# Altium schematic sheet ELRS_E19.SchDoc
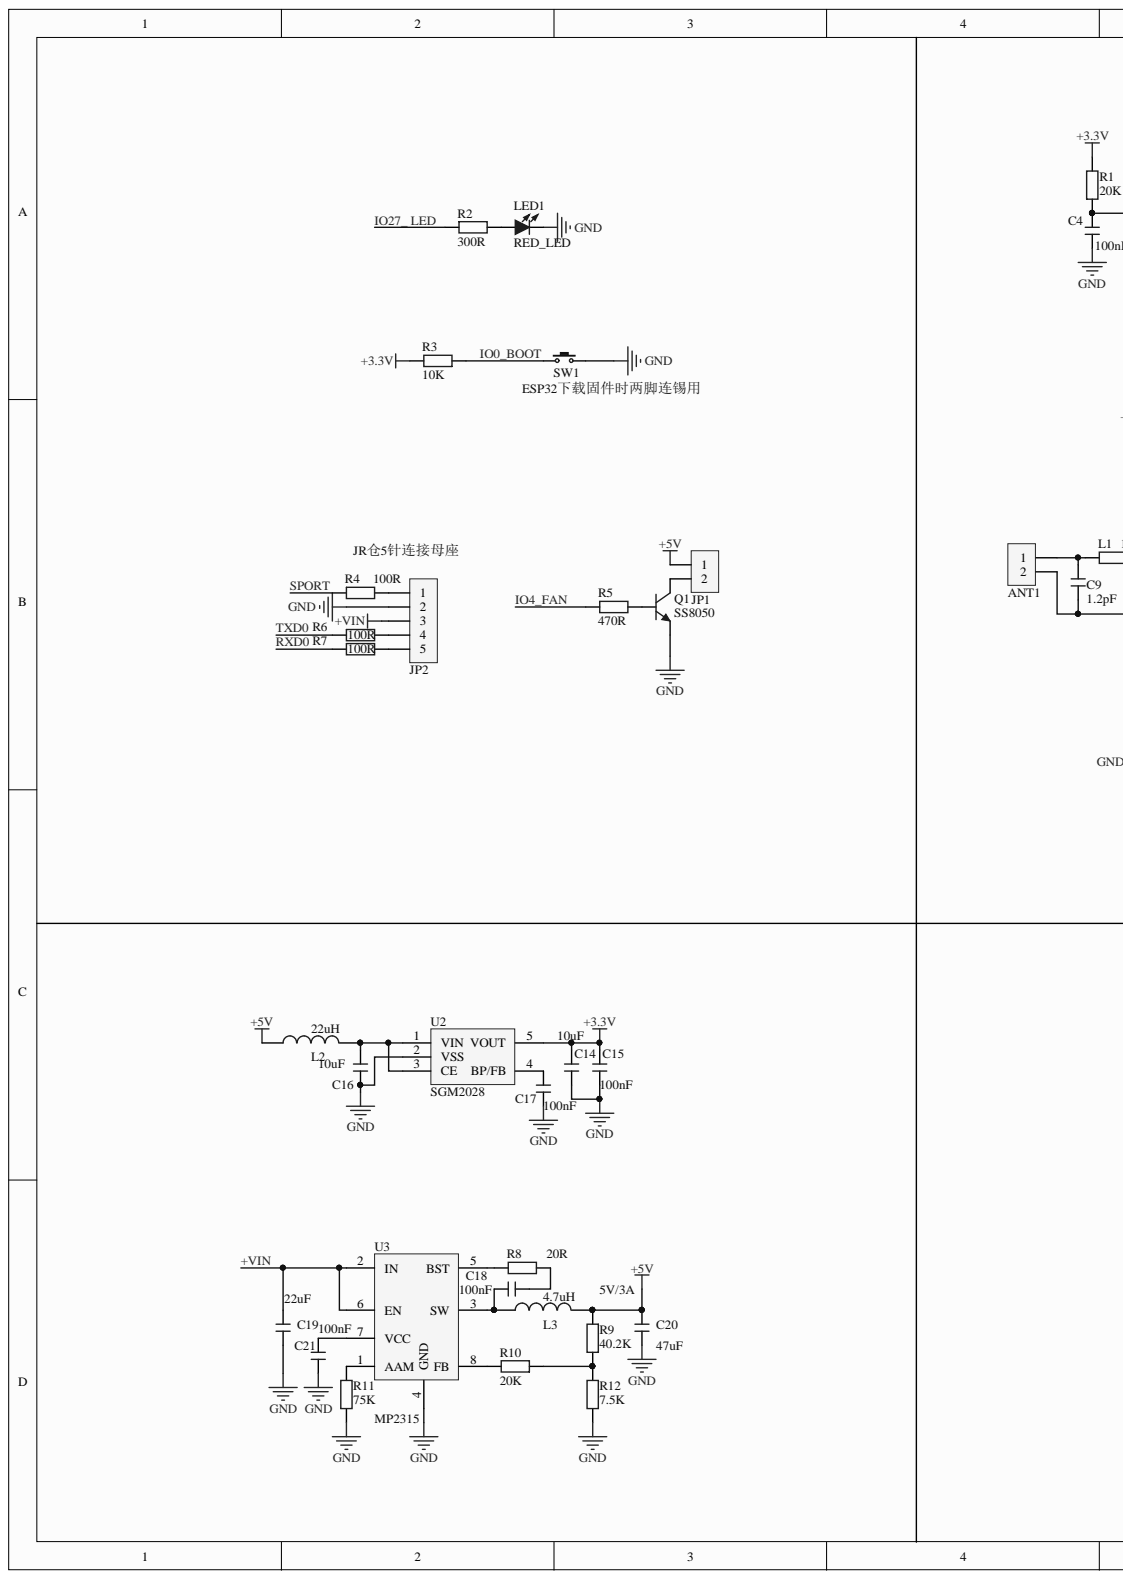
[diagram p0: ELRS_E19.SchDoc, left]
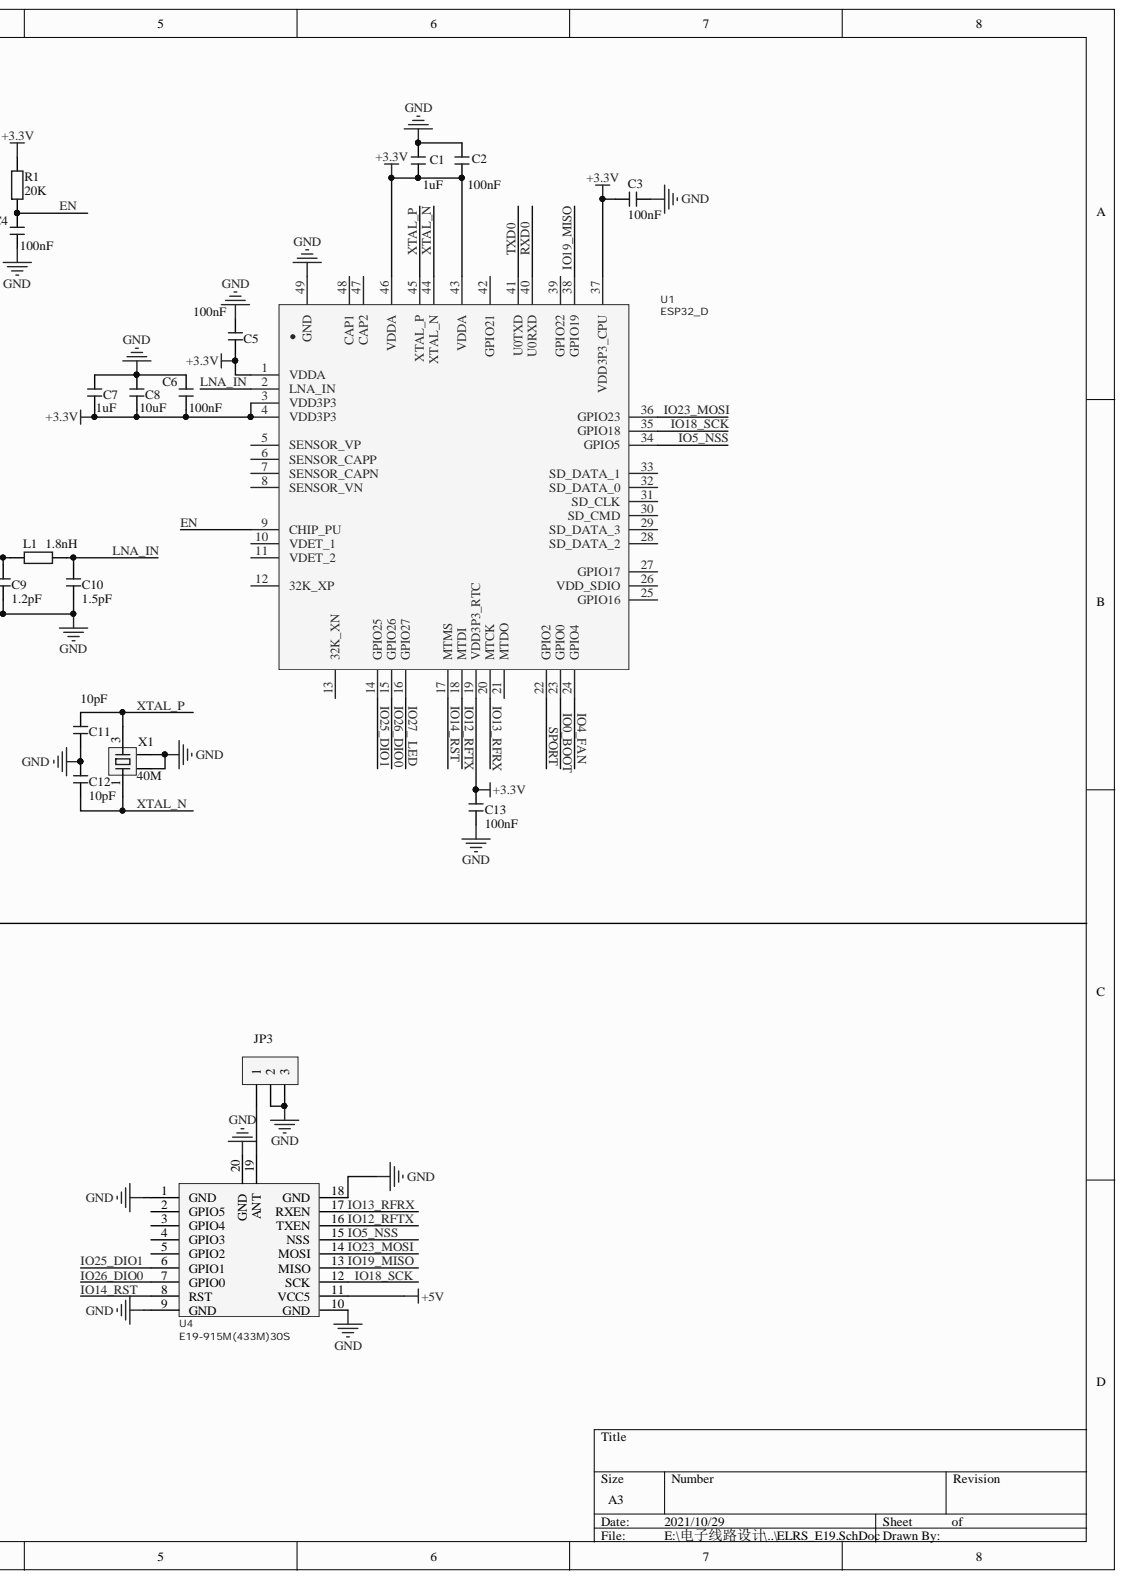
[diagram p1: ELRS_E19.SchDoc, right]

## Parts
ANT1  (no value)  [MINI_ANT]
C1  1uF  [C0402S]
C2  100nF  [C0402S]
C3  100nF  [C0402S]
C4  100nF  [C0402S]
C5  100nF  [C0402S]
C6  100nF  [C0402S]
C7  1uF  [C 0603_L]
C8  10uF  [C 0603_L]
C9  1.2pF  [C0402S]
C10  1.5pF  [C0402S]
C11  10pF  [C0402S]
C12  10pF  [C0402S]
C13  100nF  [C0402S]
C14  10uF  [C 0603_L]
C15  100nF  [C 0603_L]
C16  10uF  [C 0603_L]
C17  100nF  [C 0402_L]
C18  100nF  [C0402S]
C19  22uF  [C 1210_L]
C20  47uF  [C 1210_L]
C21  100nF  [C0402S]
JP1  HDR-1X2  [MX 1.25-LI-2P]  (value not drawn)
JP2  B2541BW-5P  [B2541BW-5P]  (value not drawn)
JP3  IPEX  [IPEX]  (value not drawn)
L1  1.8nH  [L0402S]
L2  22uH  [L 0603]
L3  4.7uH  [CD42]
LED1  RED_LED  [LED0805TB]
Q1  SS8050  [SOT23-3L]
R1  20K  [R0402S]
R2  300R  [R0402S]
R3  10K  [R0402S]
R4  100R  [R0402S]
R5  470R  [R0402S]
R6  100R  [R0402S]
R7  100R  [R0402S]
R8  20R  [R0402S]
R9  40.2K  [R0402S]
R10  20K  [R0402S]
R11  75K  [R0402S]
R12  7.5K  [R0402S]
SW1  FLASH  [jumper-2.0]  (value not drawn)
U1  ESP32_D  [ESP32-PICO-D4]
U2  SGM2028  [SOT23-5L]
U3  MP2315  [SOT23-5M]
U4  E19-915M(433M)30S  [E19_2IN1]
X1  40M  [OSC 2025-4P]

## Nets
+3.3V: C1.2 C2.2 C3.1 C5.1 C6.1 C7.1 C8.1 C13.2 C14.2 C15.2 R1.1 R3.1 U1.1(VDDA) U1.3(VDD3P3) U1.4(VDD3P3) U1.19(VDD3P3_RTC) U1.37(VDD3P3_CPU) U1.43(VDDA) U1.46(VDDA) U2.5(VOUT)
+5V: C20.2 JP1.1 L2.1 L3.2 R9.2 U4.11(VCC5)
GND: ANT1.2 C1.1 C2.1 C3.2 C4.1 C5.2 C6.2 C7.2 C8.2 C9.2 C10.2 C11.2 C12.1 C13.1 C14.1 C15.1 C16.1 C17.1 C19.1 C20.1 C21.1 JP2.2 JP3.2 JP3.3 LED1.2 Q1.2 R11.2 R12.1 SW1.2 U1.49(GND) U2.2(VSS) U3.4(GND) U4.1(GND) U4.9(GND) U4.10(GND) U4.18(GND) U4.20(GND) X1.2 X1.4
+VIN: C19.2 JP2.3 U3.2(IN) U3.6(EN)
EN: C4.2 R1.2 U1.9(CHIP_PU)
IO0_BOOT: R3.2 SW1.1 U1.23(GPIO0)
IO4_FAN: R5.2 U1.24(GPIO4)
IO5_NSS: U1.34(GPIO5) U4.15(NSS)
IO12_RFTX: U1.18(MTDI) U4.16(TXEN)
IO13_RFRX: U1.20(MTCK) U4.17(RXEN)
IO14_RST: U1.17(MTMS) U4.8(RST)
IO18_SCK: U1.35(GPIO18) U4.12(SCK)
IO19_MISO: U1.38(GPIO19) U4.13(MISO)
IO23_MOSI: U1.36(GPIO23) U4.14(MOSI)
IO25_DIO1: U1.14(GPIO25) U4.6(GPIO1)
IO26_DIO0: U1.15(GPIO26) U4.7(GPIO0)
IO27_ LED: R2.2 U1.16(GPIO27)
LNA_IN: C10.1 L1.2 U1.2(LNA_IN)
RXD0: R7.2 U1.40(U0RXD)
SPORT: R4.2 U1.22(GPIO2)
TXD0: R6.2 U1.41(U0TXD)
XTAL_N: C12.2 U1.44(XTAL_N) X1.1
XTAL_P: C11.1 U1.45(XTAL_P) X1.3
NetANT1_1: ANT1.1 C9.1 L1.1
NetC16_2: C16.2 L2.2 U2.1(VIN) U2.3(CE)
NetC17_2: C17.2 U2.4(BP/FB)
NetC18_1: C18.1 R8.2
NetC18_2: C18.2 L3.1 U3.3(SW)
NetC21_2: C21.2 U3.7(VCC)
NetJP1_2: JP1.2 Q1.3
NetJP2_1: JP2.1 R4.1
NetJP2_4: JP2.4 R6.1
NetJP2_5: JP2.5 R7.1
NetJP3_1: JP3.1 U4.19(ANT)
NetLED1_1: LED1.1 R2.1
NetQ1_1: Q1.1 R5.1
NetR8_1: R8.1 U3.5(BST)
NetR9_1: R9.1 R10.2 R12.2
NetR10_1: R10.1 U3.8(FB)
NetR11_1: R11.1 U3.1(AAM)

Not connected: U1 5(SENSOR_VP) 6(SENSOR_CAPP) 7(SENSOR_CAPN) 8(SENSOR_VN) 10(VDET_1) 11(VDET_2) 12(32K_XP) 13(32K_XN) 21(MTDO) 25(GPIO16) 26(VDD_SDIO) 27(GPIO17) 28(SD_DATA_2) 29(SD_DATA_3) 30(SD_CMD) 31(SD_CLK) 32(SD_DATA_0) 33(SD_DATA_1) 39(GPIO22) 42(GPIO21) 47(CAP2) 48(CAP1); U4 2(GPIO5) 3(GPIO4) 4(GPIO3) 5(GPIO2)

## Library data of the parts
ANT1 JP1 = Header 2: 2P接插件; 版权归属: 源创客; BOM名称: 2P接插件; Component Kind: Standard; Library Name: 4.3   - 接插件-脚距1.5.SchLib; 学习资源: www.ooocke.com
C1-C21 = C: 无极性贴片电容; 版权归属: 源创客; BOM名称: 无极性贴片电容; Component Kind: Standard; Library Name: 3.1   - 电阻-电容-电感.SchLib; 学习资源: www.ooocke.com
JP2 = Header 5: 5P接插件; 版权归属: 源创客; BOM名称: 5P接插件; Component Kind: Standard; Library Name: 4.5   - 接插件-脚距2.54.SchLib; 学习资源: www.ooocke.com
JP3 = Header 3: 3P接插件; 版权归属: 源创客; BOM名称: 3P接插件; Component Kind: Standard; Library Name: 4.3   - 接插件-脚距1.5.SchLib; 学习资源: www.ooocke.com
L1 R1-R12 = R: 贴片电阻; 版权归属: 源创客; BOM名称: 贴片电阻; Component Kind: Standard; Library Name: 3.1   - 电阻-电容-电感.SchLib; 学习资源: www.ooocke.com
L2 L3 = L: 小功率贴片电感; 版权归属: 源创客; BOM名称: 小功率贴片电感; Component Kind: Standard; Library Name: 3.1   - 电阻-电容-电感.SchLib; 学习资源: www.ooocke.com
LED1 = LED-SMD: 贴片LED; 版权归属: 源创客; BOM名称: 贴片LED; Component Kind: Standard; Library Name: 9.1   - LED.SchLib; 学习资源: www.ooocke.com
Q1 = 8050-SMD: 高频放大-NPN型; 版权归属: 源创客; 属性: 40V 1.5A 1W 100MHZ; BOM名称: 高频放大-NPN型; Component Kind: Standard; Library Name: 6.2   - 三极管.SchLib; 学习资源: www.ooocke.com
SW1 = TSW DIP-6x6_V: 侧按直插6x6轻触开关; 版权归属: 源创客; BOM名称: 直插6x6轻触开关; Component Kind: Standard; Library Name: 5.1   - 按键-开关.SchLib; 学习资源: www.ooocke.com
U4 = LORA-SX1276M: Component Kind: Standard; Library Name: Schlib.SchLib
X1 = XTAL-4P: 4脚无源晶振; 版权归属: 源创客; BOM名称: 4脚无源晶振; Component Kind: Standard; Library Name: 10.1 - 保险丝-晶振.SchLib; 学习资源: www.ooocke.com
U1 = ESP32_D: Component Kind: Standard; Library Name: Schlib.SchLib
U2 = SGM2028: Component Kind: Standard; Library Name: Schlib.SchLib
U3 = MP2315: Component Kind: Standard; Library Name: Schlib.SchLib
